# Altium schematic sheet IR.SchDoc
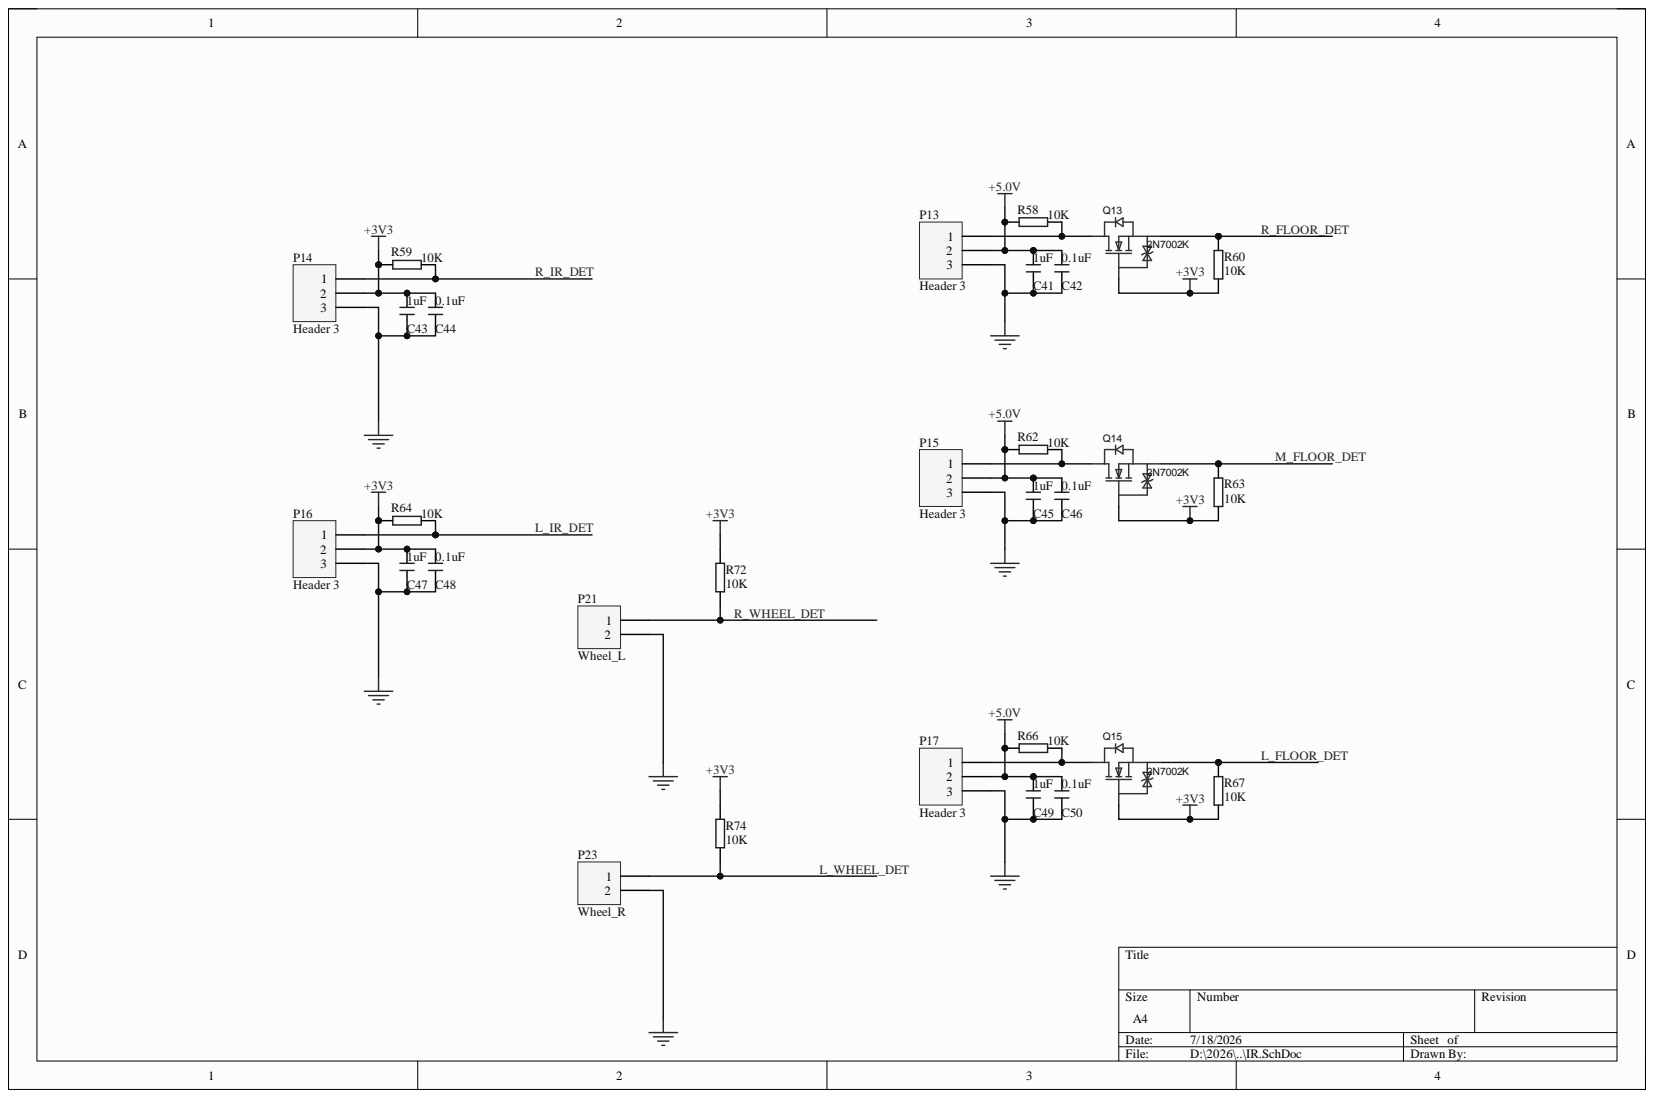
[diagram p0: IR.SchDoc]

## Parts
C41  1uF  [C 0603_L]
C42  0.1uF  [C 0603_L]
C43  1uF  [C 0603_L]
C44  0.1uF  [C 0603_L]
C45  1uF  [C 0603_L]
C46  0.1uF  [C 0603_L]
C47  1uF  [C 0603_L]
C48  0.1uF  [C 0603_L]
C49  1uF  [C 0603_L]
C50  0.1uF  [C 0603_L]
P13  Header 3  [PH2.0-LS-3P]
P14  Header 3  [PH2.0-LS-3P]
P15  Header 3  [PH2.0-LS-3P]
P16  Header 3  [PH2.0-LS-3P]
P17  Header 3  [PH2.0-LS-3P]
P21  Wheel_L  [PH2.0-LS-2P]
P23  Wheel_R  [PH2.0-LS-2P]
Q13  2N7002K  [SOT-23_L2.9-W1.3-P1.90-LS2.4-BR]
Q14  2N7002K  [SOT-23_L2.9-W1.3-P1.90-LS2.4-BR]
Q15  2N7002K  [SOT-23_L2.9-W1.3-P1.90-LS2.4-BR]
R58  10K  [R 0603_L]
R59  10K  [R 0603_L]
R60  10K  [R 0603_L]
R62  10K  [R 0603_L]
R63  10K  [R 0603_L]
R64  10K  [R 0603_L]
R66  10K  [R 0603_L]
R67  10K  [R 0603_L]
R72  10K  [R 0603_L]
R74  10K  [R 0603_L]

## Nets
+3V3: C43.1 C44.1 C47.1 C48.1 P14.2 P16.2 Q13.1 Q14.1 Q15.1 R59.1 R60.2 R63.2 R64.1 R67.2 R72.2 R74.2
+5.0V: C41.1 C42.1 C45.1 C46.1 C49.1 C50.1 P13.2 P15.2 P17.2 R58.1 R62.1 R66.1
GND: C41.2 C42.2 C43.2 C44.2 C45.2 C46.2 C47.2 C48.2 C49.2 C50.2 P13.3 P14.3 P15.3 P16.3 P17.3 P21.2 P23.2
L_FLOOR_DET: Q15.2 R67.1
L_IR_DET: P16.1 R64.2
L_WHEEL_DET: P23.1 R74.1
M_FLOOR_DET: Q14.2 R63.1
R_FLOOR_DET: Q13.2 R60.1
R_IR_DET: P14.1 R59.2
R_WHEEL_DET: P21.1 R72.1
NetP13_1: P13.1 Q13.3 R58.2
NetP15_1: P15.1 Q14.3 R62.2
NetP17_1: P17.1 Q15.3 R66.2

## Library data of the parts
C41-C50 = C: 贴片电容; Component Kind: Standard; Library Name: GS_SchLib.SchLib
P13-P17 = Header 3: Header, 3-Pin; Component Kind: Standard; LatestRevisionDate: 17-Jul-2002; LatestRevisionNote: Re-released for DXP Platform.; Library Name: Miscellaneous Connectors.IntLib; Signal Integrity: Connector; Publisher: Altium Limited
P21 P23 = Header 2: Header, 2-Pin; Component Kind: Standard; LatestRevisionDate: 17-Jul-2002; LatestRevisionNote: Re-released for DXP Platform.; Library Name: Miscellaneous Connectors.IntLib; Signal Integrity: Connector; Publisher: Altium Limited
Q13-Q15 = 2N7002K: 数量:1个N沟道;漏源电压(Vdss):60V;连续漏极电流(Id):300mA;导通电阻(RDS(on)):2Ω@10V;耗散功率(Pd):350mW;阈值电压(Vgs(th)):2.5V@1mA;; Manufacturer: EIC; AddInBom: yes; Current - Continuous Drain(Id): 300mA; Drain to Source Voltage: 60V; Gate Charge(Qg): 800pC@4.5V; Input Capacitance(Ciss): 50pF; ModelId: c62791f0466c4ae2a892c056b845b0ca聨0819f05c4eef4c71ace90d822a990e87; ModelName: SOT-23-3P_L2.9-W1.3-H1.0-LS2.4-P0.95; ModelTransform: 98.64468,114.173,0,180,0,0,0.001,-0.002,0; Number: 1个N沟道; Output Capacitance(Coss): 25pF; Pd - Power Dissipation: 350mW; RDS(on): 2Ω@10V; Reverse Transfer Capacitance (Crss@Vds): 5pF; Symbol: 2N7002K_C2978790; Type: N沟道; Component Kind: Standard; ConvertToPcb: yes; Datasheet: https://item.szlcsc.com/datasheet/2N7002K/3393615.html; Device: 2N7002K; FootprintName: SOT-23_L2.9-W1.3-P1.90-LS2.4-BR; Gate Threshold Voltage (Vgs(th)): 2.5V@1mA; JLCPCB Part Class: Extended Part; LCSC Part Name: N沟道增强型MOSFET,电流:300mA,耐压:60V; ManufacturerPart: 2N7002K; Operating Temperature: -55℃~+150℃; Supplier: LCSC; Supplier Footprint: SOT-23; SupplierPart: C2978790
R58-R60 R62-R64 R66 R67 R72 R74 = RES3-SMD: SMD筿; Component Kind: Standard; Library Name: Orson SCH.SCHLIB
